# Altium schematic sheet controller.SchDoc
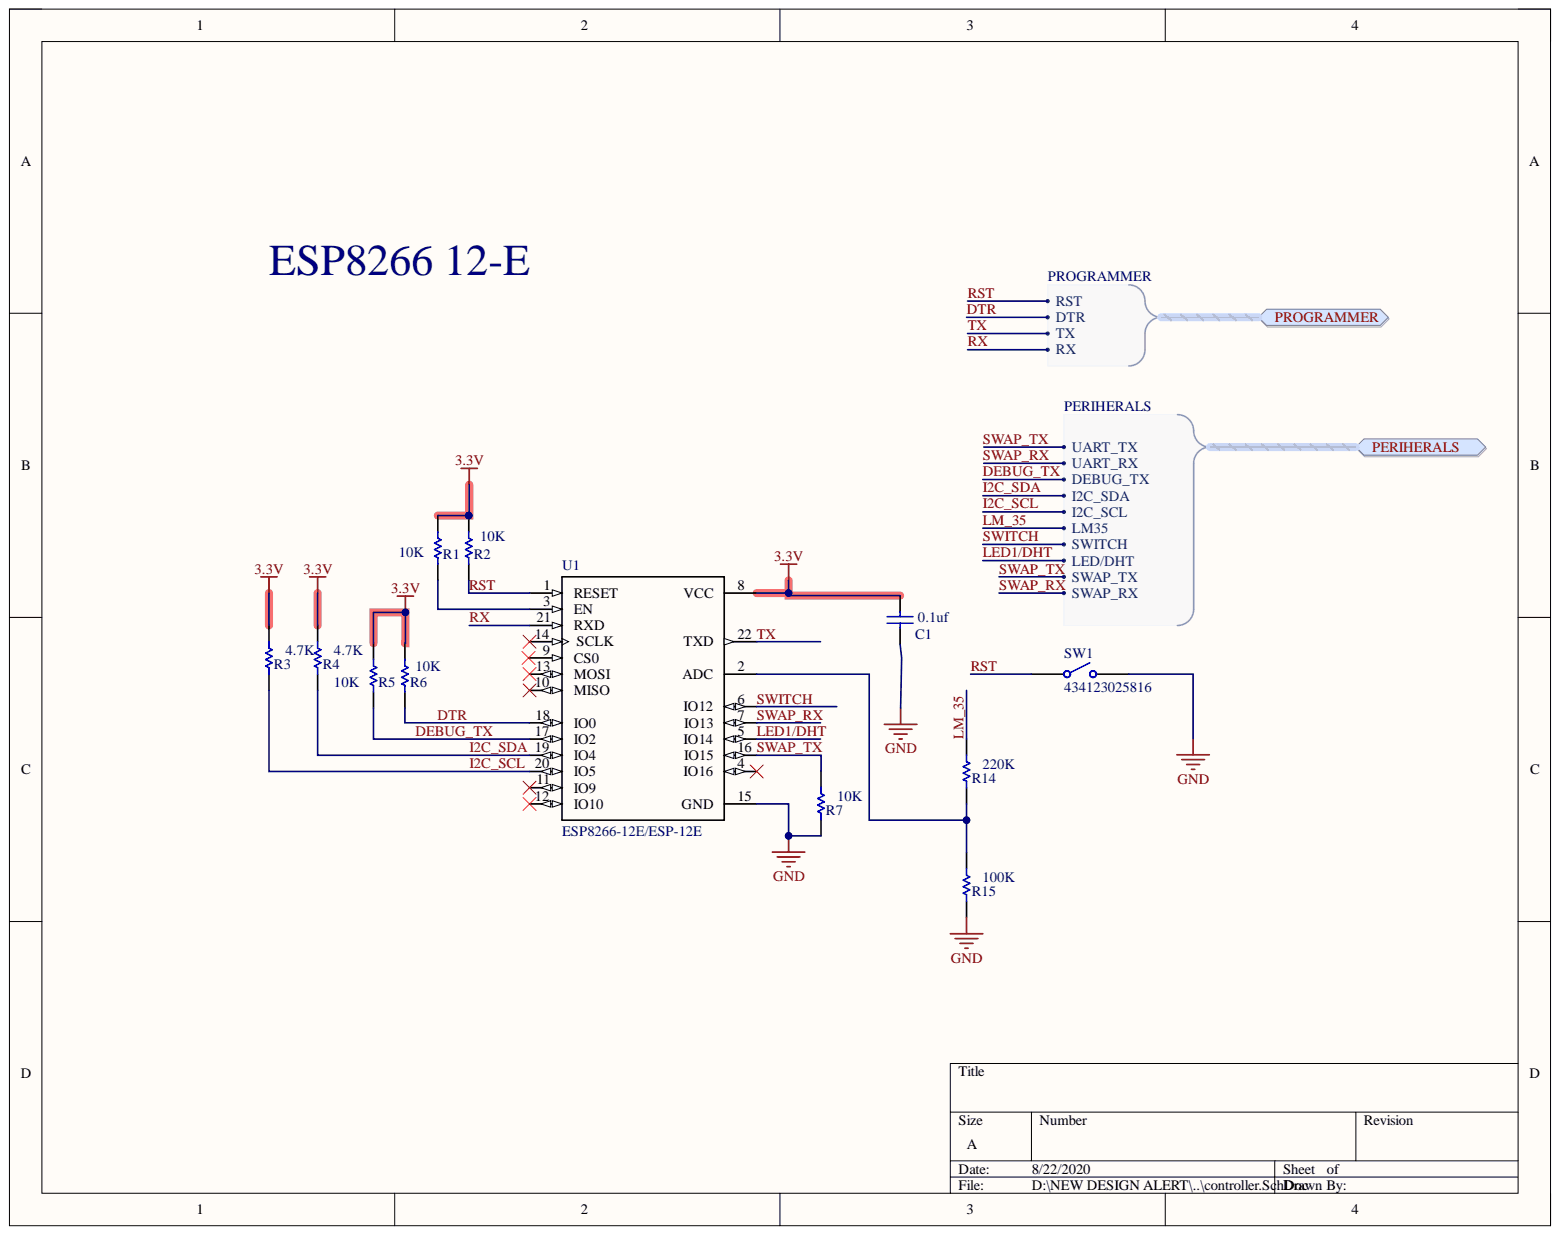
[diagram p0: controller.SchDoc]

## Parts
C1  0.1uf  [CAPC0603]
R1  10K  [RESC0603]
R2  10K  [RESC0603]
R3  4.7K  [RESC0603]
R4  4.7K  [RESC0603]
R5  10K  [RESC0603]
R6  10K  [RESC0603]
R7  10K  [RESC0603]
R14  220K  [RESC0603]
R15  100K  [RESC0603]
SW1  434123025816  [434123025816]
U1  ESP8266-12E/ESP-12E  [*XCVR_ESP8266-12E/ESP-12E]

## Ports
PERIHERALS, PERIHERALS.DEBUG_TX, PERIHERALS.I2C_SCL, PERIHERALS.I2C_SDA, PERIHERALS.LED/DHT, PERIHERALS.LM35, PERIHERALS.SWAP_RX, PERIHERALS.SWAP_TX, PERIHERALS.SWITCH, PERIHERALS.UART_RX, PERIHERALS.UART_TX, PROGRAMMER, PROGRAMMER.DTR, PROGRAMMER.RST, PROGRAMMER.RX, PROGRAMMER.TX

## Nets
3.3V: C1.1 R1.2 R2.2 R3.2 R4.2 R5.2 R6.2 U1.8(VCC)
GND: C1.2 R7.1 R15.1 SW1.2 U1.15(GND)
DEBUG_TX: R5.1 U1.17(IO2) ; port PERIHERALS
DTR: R6.1 U1.18(IO0) ; port PROGRAMMER
I2C_SCL: R3.1 U1.20(IO5) ; port PERIHERALS
I2C_SDA: R4.1 U1.19(IO4) ; port PERIHERALS
LED1/DHT: U1.5(IO14) ; port PERIHERALS
LM_35: R14.2 ; port PERIHERALS
RST: R2.1 SW1.1 U1.1(RESET) ; port PROGRAMMER
RX: U1.21(RXD) ; port PROGRAMMER
SWAP_RX: U1.7(IO13) ; port PERIHERALS
SWAP_TX: R7.2 U1.16(IO15) ; port PERIHERALS
SWITCH: U1.6(IO12) ; port PERIHERALS
TX: U1.22(TXD) ; port PROGRAMMER
NetR1_1: R1.1 U1.3(EN)
NetR14_1: R14.1 R15.2 U1.2(ADC)
N00001: ; port PERIHERALS
N00002: ; port PERIHERALS

Not connected: U1 4(IO16) 9(CS0) 10(MISO) 11(IO9) 12(IO10) 13(MOSI) 14(SCLK)

## Library data of the parts
C1 = CMP-2007-04765-1: Manufacturer: AVX Interconnect / Elco; Part Number: 08055C104KAJ2A; Component Kind: Standard; Library Name: Miscellaneous Devices.IntLib
R1-R7 R14 R15 = CMP-1013-00215-1: 2R55 0.125W 1% 0805 (2012 Metric)  SMD; Case-EIA: 0805; Case-Metric: 2012; Value: 2.55 Ohm; Component Kind: Standard; Library Name: Components - 22.01.19 - 11.39.SchLib; Power: 0.125 W; Technology: SMT; Tolerance: 1 %
SW1 = CMP-1460-00004-1: WS-TASV J-Bend SMD Tact Switch 6.0X3.8 mm; Manufacturer: Wuerth Elektronik; NoPins: 02; Component Kind: Standard; ComponentLink1Description: Manufacturer URL; ComponentLink1URL: http://www.we-online.com; ComponentLink2Description: Datasheet; ComponentLink2URL: http://katalog.we-online.de/em/datasheet/434123025816.pdf; Library Name: Altium Content Vault; PackageReference: 434123025816; PartNumber: 434123025816
U1 = ESP8266-12E/ESP-12E: This WiFi module has a 32-bit MCU micro and clock speeds supporting 80 MHz or 160 MHz. Supports the RTOS and integrated Wi-Fi MAC/BB/RF/PA/LNA, and has an on-board antenna.; MF: AI-Thinker; Availability: Unavailable; Component Kind: Standard; Library Name: ESP8266-12E_ESP-12E.IntLib; MP: ESP8266-12E/ESP-12E; Package: None; Price: None; SnapEDA_Link: https://www.snapeda.com/parts/ESP8266-12E/ESP-12E/AI-Thinker/view-part/?ref=snap
